# Altium schematic sheet USB3_PHY.SchDoc
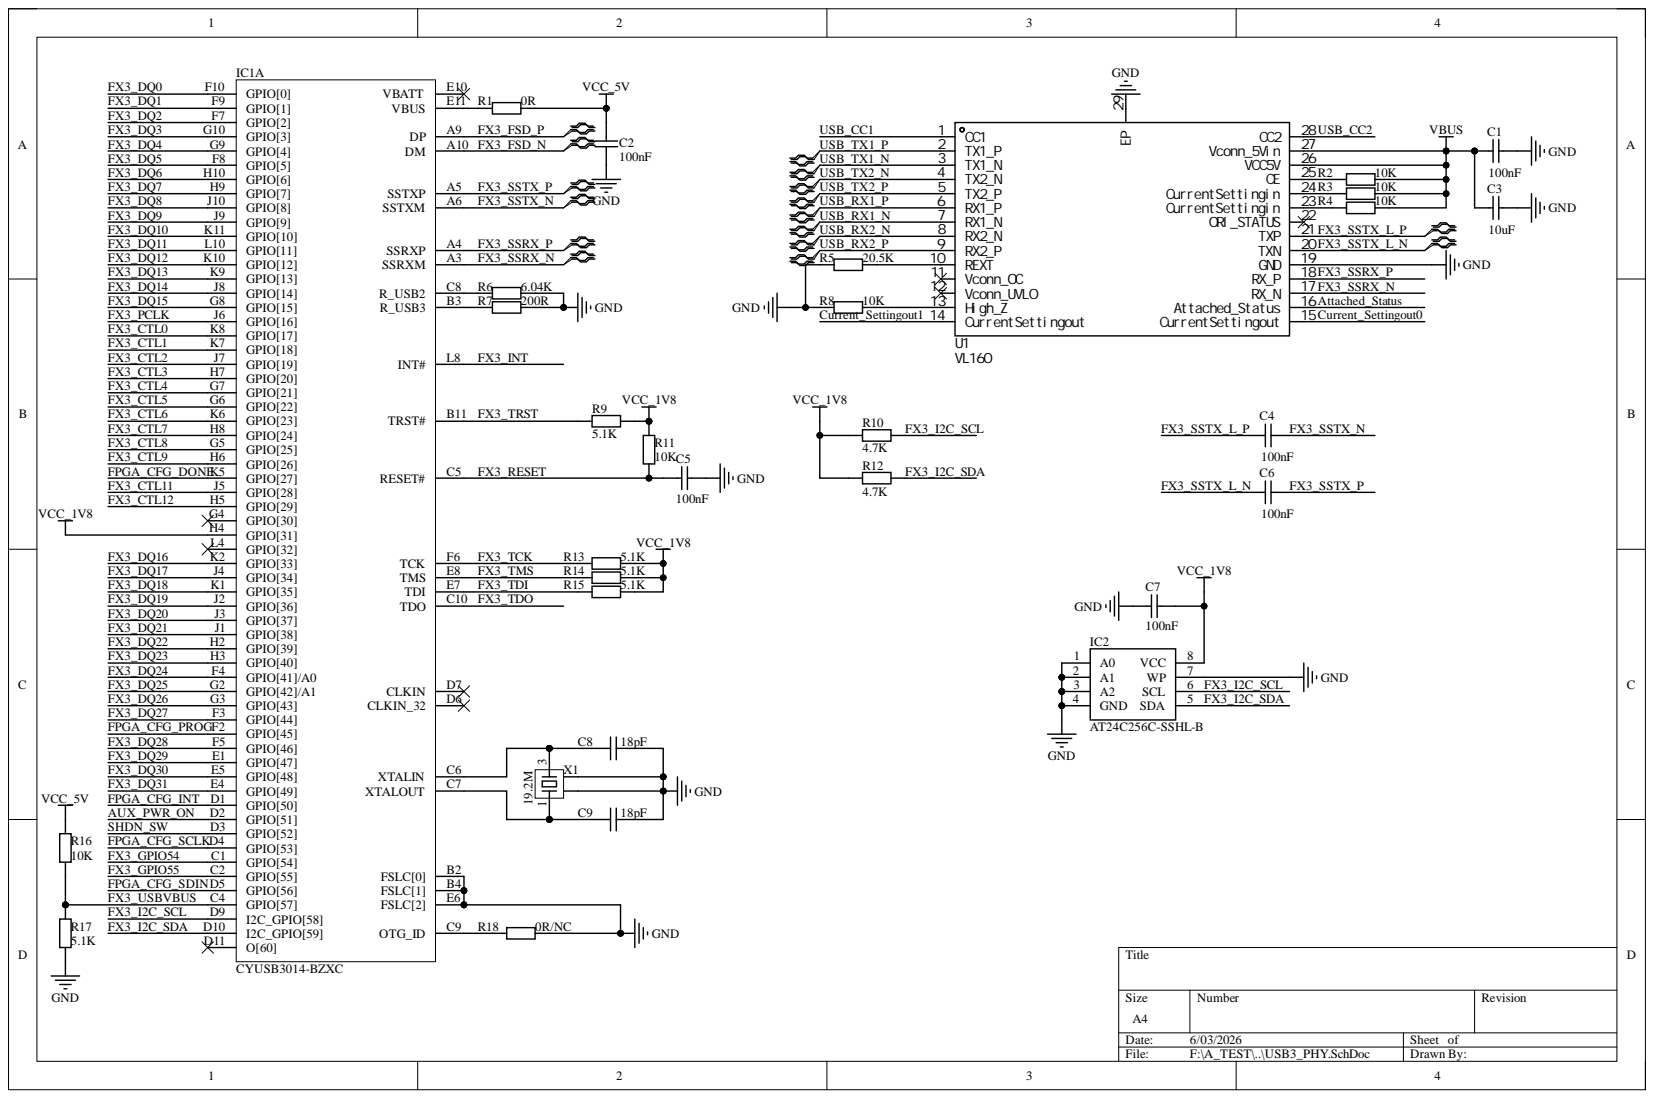
[diagram p0: USB3_PHY.SchDoc]

## Parts
C1  100nF  [C 0402_L]
C2  100nF  [C 0402_L]
C3  10uF  [C 0603_L]
C4  100nF  [C 0402_L]
C5  100nF  [C 0402_L]
C6  100nF  [C 0402_L]
C7  100nF  [C 0402_L]
C8  18pF  [C 0402_L]
C9  18pF  [C 0402_L]
IC1  CYUSB3014-BZXC  [BGA121C80P11X11_1000X1000X120]  (part A)
IC2  AT24C256C-SSHL-B  [SOIC127P600X175-8N]
R1  0R  [R 0402_L]
R2  10K  [R 0402_L]
R3  10K  [R 0402_L]
R4  10K  [R 0402_L]
R5  20.5K  [R 0402_L]
R6  6.04K  [R 0402_L]
R7  200R  [R 0402_L]
R8  10K  [R 0402_L]
R9  5.1K  [R 0402_L]
R10  4.7K  [R 0402_L]
R11  10K  [R 0402_L]
R12  4.7K  [R 0402_L]
R13  5.1K  [R 0402_L]
R14  5.1K  [R 0402_L]
R15  5.1K  [R 0402_L]
R16  10K  [R 0402_L]
R17  5.1K  [R 0402_L]
R18  0R/NC  [R 0402_L]
U1  VL160  [MQFN-28_L4.5-W3.5-P0.40-BL-EP]
X1  19.2M  [OSC 3225-4P]

## Nets
GND: C1.1 C2.1 C3.1 C5.2 C7.1 C8.1 C9.1 IC1.B2(FSLC[0]) IC1.B4(FSLC[1]) IC1.E6(FSLC[2]) IC2.1(A0) IC2.2(A1) IC2.3(A2) IC2.4(GND) IC2.7(WP) R5.1 R6.1 R7.1 R8.1 R17.1 R18.1 U1.19(GND) U1.29(EP) X1.2 X1.4
VBUS: C1.2 C3.2 R2.2 R3.2 R4.2 U1.26(VCC5V) U1.27(Vconn_5Vin)
VCC_1V8: C7.2 IC1.H4(GPIO[31]) IC2.8(VCC) R9.1 R10.2 R11.2 R12.2 R13.1 R14.1 R15.1
VCC_5V: C2.2 R1.1 R16.2
Attached_Status: U1.16(Attached_Status)
AUX_PWR_ON: IC1.D2(GPIO[51])
Current_Settingout0: U1.15(CurrentSettingout)
Current_Settingout1: U1.14(CurrentSettingout)
FPGA_CFG_DONE: IC1.K5(GPIO[27])
FPGA_CFG_INT: IC1.D1(GPIO[50])
FPGA_CFG_PROG: IC1.F2(GPIO[45])
FPGA_CFG_SCLK: IC1.D4(GPIO[53])
FPGA_CFG_SDIN: IC1.D5(GPIO[56])
FX3_CTL0: IC1.K8(GPIO[17])
FX3_CTL1: IC1.K7(GPIO[18])
FX3_CTL2: IC1.J7(GPIO[19])
FX3_CTL3: IC1.H7(GPIO[20])
FX3_CTL4: IC1.G7(GPIO[21])
FX3_CTL5: IC1.G6(GPIO[22])
FX3_CTL6: IC1.K6(GPIO[23])
FX3_CTL7: IC1.H8(GPIO[24])
FX3_CTL8: IC1.G5(GPIO[25])
FX3_CTL9: IC1.H6(GPIO[26])
FX3_CTL11: IC1.J5(GPIO[28])
FX3_CTL12: IC1.H5(GPIO[29])
FX3_DQ0: IC1.F10(GPIO[0])
FX3_DQ1: IC1.F9(GPIO[1])
FX3_DQ2: IC1.F7(GPIO[2])
FX3_DQ3: IC1.G10(GPIO[3])
FX3_DQ4: IC1.G9(GPIO[4])
FX3_DQ5: IC1.F8(GPIO[5])
FX3_DQ6: IC1.H10(GPIO[6])
FX3_DQ7: IC1.H9(GPIO[7])
FX3_DQ8: IC1.J10(GPIO[8])
FX3_DQ9: IC1.J9(GPIO[9])
FX3_DQ10: IC1.K11(GPIO[10])
FX3_DQ11: IC1.L10(GPIO[11])
FX3_DQ12: IC1.K10(GPIO[12])
FX3_DQ13: IC1.K9(GPIO[13])
FX3_DQ14: IC1.J8(GPIO[14])
FX3_DQ15: IC1.G8(GPIO[15])
FX3_DQ16: IC1.K2(GPIO[33])
FX3_DQ17: IC1.J4(GPIO[34])
FX3_DQ18: IC1.K1(GPIO[35])
FX3_DQ19: IC1.J2(GPIO[36])
FX3_DQ20: IC1.J3(GPIO[37])
FX3_DQ21: IC1.J1(GPIO[38])
FX3_DQ22: IC1.H2(GPIO[39])
FX3_DQ23: IC1.H3(GPIO[40])
FX3_DQ24: IC1.F4(GPIO[41]/A0)
FX3_DQ25: IC1.G2(GPIO[42]/A1)
FX3_DQ26: IC1.G3(GPIO[43])
FX3_DQ27: IC1.F3(GPIO[44])
FX3_DQ28: IC1.F5(GPIO[46])
FX3_DQ29: IC1.E1(GPIO[47])
FX3_DQ30: IC1.E5(GPIO[48])
FX3_DQ31: IC1.E4(GPIO[49])
FX3_FSD_N: IC1.A10(DM)
FX3_FSD_P: IC1.A9(DP)
FX3_GPIO54: IC1.C1(GPIO[54])
FX3_GPIO55: IC1.C2(GPIO[55])
FX3_I2C_SCL: IC1.D9(I2C_GPIO[58]) IC2.6(SCL) R10.1
FX3_I2C_SDA: IC1.D10(I2C_GPIO[59]) IC2.5(SDA) R12.1
FX3_INT: IC1.L8(INT#)
FX3_PCLK: IC1.J6(GPIO[16])
FX3_RESET: C5.1 IC1.C5(RESET#) R11.1
FX3_SSRX_N: IC1.A3(SSRXM) U1.17(RX_N)
FX3_SSRX_P: IC1.A4(SSRXP) U1.18(RX_P)
FX3_SSTX_L_N: C6.2 U1.20(TXN)
FX3_SSTX_L_P: C4.2 U1.21(TXP)
FX3_SSTX_N: C4.1 IC1.A6(SSTXM)
FX3_SSTX_P: C6.1 IC1.A5(SSTXP)
FX3_TCK: IC1.F6(TCK) R13.2
FX3_TDI: IC1.E7(TDI) R15.2
FX3_TDO: IC1.C10(TDO)
FX3_TMS: IC1.E8(TMS) R14.2
FX3_TRST: IC1.B11(TRST#) R9.2
FX3_USBVBUS: IC1.C4(GPIO[57]) R16.1 R17.2
SHDN_SW: IC1.D3(GPIO[52])
USB_CC1: U1.1(CC1)
USB_CC2: U1.28(CC2)
USB_RX1_N: U1.7(RX1_N)
USB_RX1_P: U1.6(RX1_P)
USB_RX2_N: U1.8(RX2_N)
USB_RX2_P: U1.9(RX2_P)
USB_TX1_N: U1.3(TX1_N)
USB_TX1_P: U1.2(TX1_P)
USB_TX2_N: U1.4(TX2_N)
USB_TX2_P: U1.5(TX2_P)
NetC8_2: C8.2 IC1.C6(XTALIN) X1.3
NetC9_2: C9.2 IC1.C7(XTALOUT) X1.1
NetIC1_B3: IC1.B3(R_USB3) R7.2
NetIC1_C8: IC1.C8(R_USB2) R6.2
NetIC1_C9: IC1.C9(OTG_ID) R18.2
NetIC1_E11: IC1.E11(VBUS) R1.2
NetR2_1: R2.1 U1.25(CE)
NetR3_1: R3.1 U1.24(CurrentSettingin)
NetR4_1: R4.1 U1.23(CurrentSettingin)
NetR5_2: R5.2 U1.10(REXT)
NetR8_2: R8.2 U1.13(High_Z)

Not connected: IC1 D6(CLKIN_32) D7(CLKIN) D11(O[60]) E10(VBATT) G4(GPIO[30]) L4(GPIO[32]); U1 11(Vconn_OC) 12(Vconn_UVLO) 22(ORI_STATUS)

## Library data of the parts
C1-C9 = Cap: 贴片电容; Component Kind: Standard; Library Name: A_Schlib.SchLib
  C1 C2 C4-C7: value: 100nF
  C3: value: 10uF
  C8 C9: value: 18pF
IC1 = CYUSB3014-BZXC: Integrated Circuit; Manufacturer_Name: Infineon; Arrow Part Number: CYUSB3014-BZXC; Arrow Price/Stock: https://www.arrow.com/en/products/cyusb3014-bzxc/infineon-technologies-ag?utm_currency=USD&region=nac; Component Kind: Standard; Datasheet Link: https://www.infineon.com/dgdl/Infineon-CYUSB301X_CYUSB201X_EZ-USB_FX3_SUPERSPEED_USB_CONTROLLER-DataSheet-v01_00-EN.pdf?fileId=8ac78c8c7d0d8da4017d0eca1e7442aa; Height: 1.2mm; Library Name: SamacSys.SchLib; Manufacturer_Part_Number: CYUSB3014-BZXC; Mouser Part Number: 727-CYUSB3014-BZXC; Mouser Price/Stock: https://www.mouser.co.uk/ProductDetail/Infineon-Technologies/CYUSB3014-BZXC?qs=xP2cAaN2A3Wt%252BQariFLwRg%3D%3D
IC2 = AT24C256C-SSHL-B: Integrated Circuit; Manufacturer_Name: Microchip; Arrow Part Number: AT24C256C-SSHL-B; Arrow Price/Stock: https://www.arrow.com/en/products/at24c256c-sshl-b/microchip-technology?region=europe; Component Kind: Standard; Datasheet Link: https://ww1.microchip.com/downloads/aemDocuments/documents/MPD/ProductDocuments/DataSheets/AT24C256C-I%C2%B2C-Compatible-%28Two-Wire%29-Serial-EEPROM-256-Kbit-%2832%2C768x8%29-Data-Sheet-DS20006042.pdf; Height: 1.75mm; Library Name: SamacSys.SchLib; Manufacturer_Part_Number: AT24C256C-SSHL-B; Mouser Part Number: 556-AT24C256C-SSHL-B; Mouser Price/Stock: https://www.mouser.co.uk/ProductDetail/Microchip-Technology/AT24C256C-SSHL-B?qs=fzsaQExJLyRFaSKgRsy2OQ%3D%3D
R1-R18 = Res: 通用; Component Kind: Standard; Library Name: A_Schlib.SchLib
  R1: value: 0R
  R2-R4 R8 R11 R16: value: 10K
  R5: value: 20.5K
  R6: value: 6.04K
  R7: value: 200R
  R9 R13-R15 R17: value: 5.1K
  R10 R12: value: 4.7K
  R18: value: 0R/NC
U1 = VL160: Add into BOM: yes; Applications Function: -; Component Kind: Standard; Convert to PCB: yes; Datasheet: https://atta.szlcsc.com/upload/public/pdf/source/20210707/C2841472_23203D4DC0B460F77735B81667EEBB6C.pdf; Device: VL160; JLCPCB Part Class: 扩展库; LCSC Part Name: VL160; Library Name: SamacSys.SchLib; Manufacturer Part: VL160; Protocol: -; Supplier: LCSC; Supplier Footprint: MQFN-28-EP(3.5x4.5); Supplier Part: C2841472; Symbol: VL160
X1 = XTAL-4P: 4脚无源晶振; 版权归属: 封装库来自淘宝卖家 ID：黄晶宝石; BOM名称: 4脚无源晶振; Component Kind: Standard; Library Name: A_Schlib.SchLib; 学习资源: https://shop463601444.taobao.com
